# Altium schematic sheet microcontroller.SchDoc
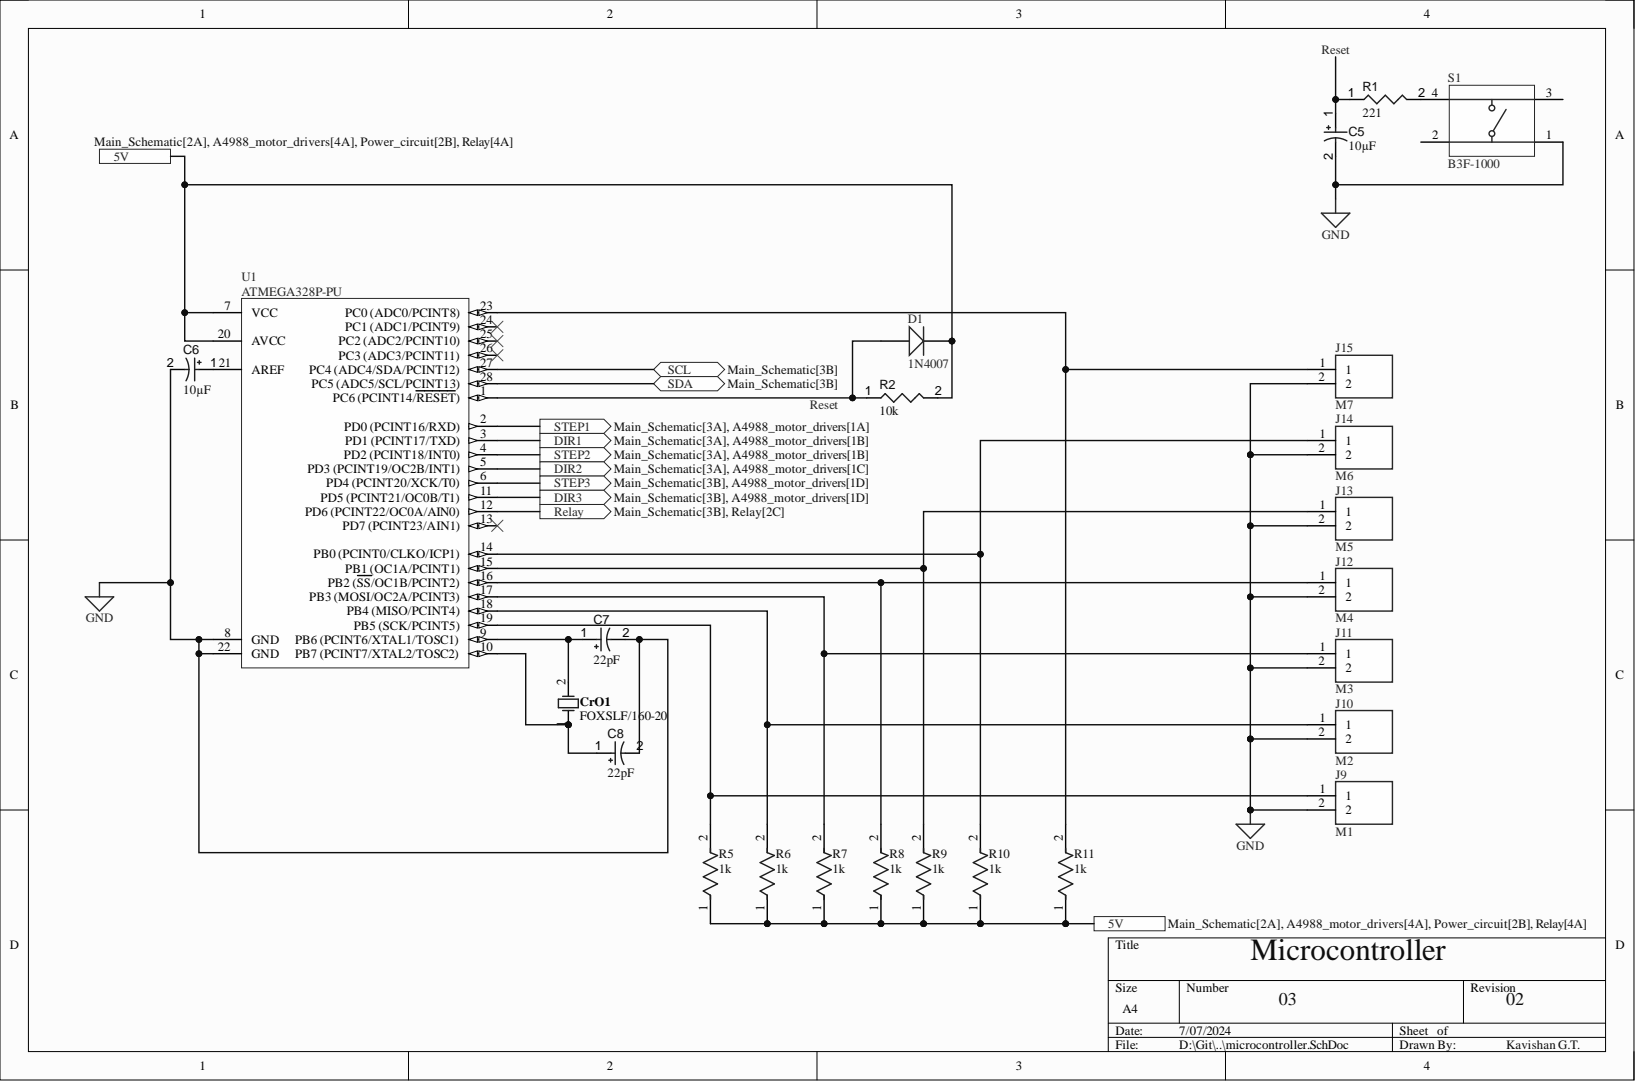
[diagram p0: microcontroller.SchDoc]

## Parts
C5  value 10µF  [CAPPR55-200-1100X500X1200]  (hidden comment: ECA1HM100)
C6  value 10µF  [CAPPR55-200-1100X500X1200]  (hidden comment: ECA1HM100)
C7  value 22pF  [CAPPR55-200-1100X500X1200]  (hidden comment: ECA1HM100)
C8  value 22pF  [CAPPR55-200-1100X500X1200]  (hidden comment: ECA1HM100)
CrO1  FOXSLF/160-20  [FOX-FOXSLF160-20]
D1  1N4007  [FAIR-DO-41-2]
J9  M1  [SHDR2W64P0X250_1X2_750X380X600P]
J10  M2  [SHDR2W64P0X250_1X2_750X380X600P]
J11  M3  [SHDR2W64P0X250_1X2_750X380X600P]
J12  M4  [SHDR2W64P0X250_1X2_750X380X600P]
J13  M5  [SHDR2W64P0X250_1X2_750X380X600P]
J14  M6  [SHDR2W64P0X250_1X2_750X380X600P]
J15  M7  [SHDR2W64P0X250_1X2_750X380X600P]
R1  value 221  [RESA60-630X240]  (hidden comment: MFR-25FBF52-221R)
R2  value 10k  [RESA60-630X240]  (hidden comment: MFR-25FBF52-10K)
R5  1k  [FP-MFR-25-MFG]
R6  1k  [FP-MFR-25-MFG]
R7  1k  [FP-MFR-25-MFG]
R8  1k  [FP-MFR-25-MFG]
R9  1k  [FP-MFR-25-MFG]
R10  1k  [FP-MFR-25-MFG]
R11  1k  [FP-MFR-25-MFG]
S1  B3F-1000  [OMRON-B3F-1000-4_V]
U1  ATMEGA328P-PU  [ATML-28P3]

## Ports
5V, DIR1, DIR2, DIR3, Relay, SCL, SDA, STEP1, STEP2, STEP3

## Nets
GND: C5.2 C6.2 C7.2 C8.2 J9.2 J10.2 J11.2 J12.2 J13.2 J14.2 J15.2 S1.1 U1.8(GND) U1.22(GND)
5V: D1.1 R2.2 R5.1 R6.1 R7.1 R8.1 R9.1 R10.1 R11.1 U1.7(VCC) U1.20(AVCC) ; port 5V
DIR1: U1.3(PD1_(PCINT17/TXD)) ; port DIR1
DIR2: U1.5(PD3_(PCINT19/OC2B/INT1)) ; port DIR2
DIR3: U1.11(PD5_(PCINT21/OC0B/T1)) ; port DIR3
Relay: U1.12(PD6_(PCINT22/OC0A/AIN0)) ; port Relay
Reset: C5.1 D1.2 R1.1 R2.1 U1.1(PC6_(PCINT14/RESET))
SCL: U1.27 ; port SCL
SDA: U1.28(PC5_(ADC5/SCL/PCINT13)) ; port SDA
STEP1: U1.2(PD0_(PCINT16/RXD)) ; port STEP1
STEP2: U1.4(PD2_(PCINT18/INT0)) ; port STEP2
STEP3: U1.6(PD4_(PCINT20/XCK/T0)) ; port STEP3
NetC6_1: C6.1 U1.21(AREF)
NetC7_1: C7.1 CrO1.2 U1.9
NetC8_1: C8.1 CrO1.1 U1.10
NetJ9_1: J9.1 R5.2 U1.19(PB5_(SCK/PCINT5))
NetJ10_1: J10.1 R6.2 U1.18(PB4_(MISO/PCINT4))
NetJ11_1: J11.1 R7.2 U1.17(PB3_(MOSI/OC2A/PCINT3))
NetJ12_1: J12.1 R8.2 U1.16(PB2_(SS/OC1B/PCINT2))
NetJ13_1: J13.1 R9.2 U1.15(PB1_(OC1A/PCINT1))
NetJ14_1: J14.1 R10.2 U1.14(PB0_(PCINT0/CLKO/ICP1))
NetJ15_1: J15.1 R11.2 U1.23(PC0_(ADC0/PCINT8))
NetR1_2: R1.2 S1.4

Not connected: S1 2 3; U1 13(PD7_(PCINT23/AIN1)) 24(PC1_(ADC1/PCINT9)) 25 26(PC3_(ADC3/PCINT11))

## Library data of the parts
C5-C8 = CMP-001-00025-7: Aluminum Electrolytic Capacitor, 10 uF, +/- 20%, 50 V, -40 to 85 degC, 2-Pin THD, RoHS, Bulk; Manufacturer: Panasonic; Ripple Current: 65mA; Voltage Rating: 50V; Capacitor Type: Electrolytic; Case/Package: Radial; Component Kind: Standard; Datasheet URL: https://industrial.panasonic.com/cdbs/www-data/pdf/RDF0000/ABA0000C1218.pdf; Datasheet Version: Rev. 05, 10/2016; Diameter: 5mm; Lead Pitch: 2mm; Manufacturer URL: http://www.panasonic.com/; Max Operating Temperature: 85°C; Min Operating Temperature: -40°C; Mounting Technology: TH; Package Description: 2-Pin Radial Lead, Dia 5 mm, Height 12 mm; Package Quantity: 200; Package Reference: CAPPR55-200-1100X500X1200; Packaging: Bulk; Pins: 2; Ripple Current (AC): 65mA; RoHS Compliant: True; Tolerance: 20%; Library Name: University of Moratuwa
CrO1 = CMP-1749-00003-1: Resistance Weld Thru-Hole Crystal, 16 MHz, -20 to 70 degC, 2-Pin THD, RoHS, Bulk; Case\Package: Axial; Power Rating: 250 mW; Resistance: 221 kohm; Tolerance: 1%; Voltage Rating: 250 V; Component Kind: Standard; ComponentLink1Description: Manufacturer URL; ComponentLink1URL: http://www.foxonline.com/; ComponentLink2Description: Datasheet; ComponentLink2URL: http://www.foxonline.com/pdfs/hc49slf.pdf; DatasheetVersion: Mar-15; Length: 0.248 inch; Library Name: Altium Content Vault; Max Operating Temperature: 155 degC; Min Operating Temperature: -55 degC; Mount: Through Hole; Package Quantity: 200; PackageDescription: 2-Pin Through Hole Device, Body 11.35 x 4.65 mm; PackageReference: FOXSLF160-20; Packaging: Bulk; RoHSCompliant: true
D1 = CMP-004-00007-2: General Purpose Rectifier, 1.1 V, 1.0 A, -55 to 175 degC, 2-Pin DO-41, RoHS, Tape and Reel; Manufacturer: Fairchild; Elements: 1; Forward Current: 1A; Forward Voltage: 1.1V; Max Surge Current: 30A; Package Version: Rev. 2, 09/2008; Peak Reverse Current: 5uA; Case/Package: DO-41-2; Component Kind: Standard; Datasheet URL: https://www.fairchildsemi.com/datasheets/1N/1N4007.pdf; Datasheet Version: Rev. 1.1.0, 11/2014; Manufacturer URL: https://www.fairchildsemi.com/; Max Operating Temperature: 175°C; Min Operating Temperature: -55°C; Mounting Technology: TH; Package Description: 2-Pin Axial Lead, Dia 2.375 mm, Body Length 4.63 mm; Package Quantity: 5000; Package Reference: DO-41-2; Package URL: http://www.fairchildsemi.com/dwg/DO/DO41A.pdf; Packaging: TapeandReel; Pins: 2; RoHS Compliant: True; Library Name: University of Moratuwa
J9-J15 = B2B-EH-A: Connector; Manufacturer_Name: JST (JAPAN SOLDERLESS TERMINALS); Component Kind: Standard; Datasheet Link: http://www.jst-mfg.com/product/pdf/eng/eEH.pdf; Height: 6mm; Manufacturer_Part_Number: B2B-EH-A
R1 = CMP-009-00051-4: Axial Resistor, 221 Ohm, +/- 1%, 250 mW, -55 to 155 degC, 2-Pin THD, RoHS, Bulk; Manufacturer: Yageo; Power: 250mW; Voltage Rating: 250V; Case/Package: Axial; Component Kind: Standard; Datasheet URL: http://www.yageo.com/documents/recent/Yageo%20LR_MFR_2013.pdf; Datasheet Version: Apr-13; Manufacturer URL: http://www.yageo.com/; Max Operating Temperature: 155°C; Min Operating Temperature: -55°C; Mounting Technology: TH; Package Description: 2-Pin Axial Lead, Dia 2.4 mm, Body Length 6.3 mm; Package Quantity: 200; Package Reference: MFR-25; Packaging: Bulk; RoHS Compliant: True; Tolerance: 1%; Library Name: University of Moratuwa
R2 = CMP-009-00047-4: Axial Resistor, 10 KOhm, +/- 1%, 0.25 W, -55 to 155 degC, 2-Pin THD, RoHS, Bulk; Manufacturer: Yageo; Power: 250mW; Voltage Rating: 250V; Case/Package: Axial; Component Kind: Standard; Datasheet URL: http://www.yageo.com/documents/recent/Yageo%20LR_MFR_2013.pdf; Datasheet Version: Apr-13; Manufacturer URL: http://www.yageo.com/; Max Operating Temperature: 155°C; Min Operating Temperature: -55°C; Mounting Technology: TH; Package Description: 2-Pin Axial Lead, Dia 2.4 mm, Body Length 6.3 mm; Package Quantity: 200; Package Reference: MFR-25; Packaging: Bulk; RoHS Compliant: True; Tolerance: 1%; Library Name: University of Moratuwa
R5-R11 = CMP-03413-000001-1: RES 1.18K OHM 1/4W 1% AXIAL; Manufacturer: Yageo; Manufacturer Part Number: MFR-25FBF52-1K18; Power Rating: 0.25W; Resistance: 1.18kΩ; Temperature Coefficient: 100ppm/°C; Value: 1.18kΩ; Voltage Rating: 250V; Automotive: No; Category: Res; Component Kind: Standard; ComponentLink2Description: Datasheet; ComponentLink2URL: https://www.yageo.com/upload/media/product/products/datasheet/lr/Yageo_LR_MFR_1.pdf; Composition: Metal Film; Device Class L1: Passive Components; Device Class L2: Resistors; Device Class L3: Through-Hole Resistors; Digikey Description: RES 1.18K OHM 1/4W 1% AXIAL; Height: 2.6mm; Ipc Land Pattern Name: RESAD1000W75L680D260; Lead Free: Yes; Library Name: Altium Content Vault; Mouser Description: Metal Film Resistors 1.18K OHM 1/4W 1%; Octopart: https://octopart.com/mfr-25fbf52-1k18-yageo-56251681; Package: MFR-25; RoHS: TRUE; Series: MFR; Temperature: -55°C to +155°C; Temperature Range High: +155°C; Temperature Range Low: -55°C; Tolerance: 1%
S1 = CMP-011-00004-2: Tactile Switch, SPST-NO, 0.05 A, 24 V, -25 to 70 degC, 4-Pin THD, RoHS, Bulk; Manufacturer: Omron Electronic Components; Contact Current Rating: 50mA; Max Contact Resistance: 100mohm; Max Voltage Rating (DC): 24V; Operating Force: 100gf; Resistance: 0.1Ohm; Throw Configuration: SPST; Case/Package: B3F-1000; Component Kind: Standard; Contact Material: Gold; Contact Plating: Gold; Current Rating: 50mA; Datasheet URL: http://www.omron.com/ecb/products/pdf/en-b3f.pdf; Datasheet Version: Jan-15; Manufacturer URL: http://www.omron.com/; Max Current Rating: 50mA; Max Operating Temperature: 70°C; Min Operating Temperature: -25°C; Mounting Technology: TH; Package Description: 4-Pin Through Hole Device, Body 6 x 6 mm; Package Quantity: 1500; Package Reference: B3F-1000; Packaging: Bulk; Pins: 4; RoHS Compliant: True; Voltage Rating: 24V; Voltage Rating (DC): 24V; Library Name: University of Moratuwa
U1 = CMP-020-00004-3: 8-Bit Microcontroller with 32 KBytes In-System Programmable Flash, 23 I/Os, -40 to 85 degC, 28-Pin PDIP (28P3), RoHS, Tube; Manufacturer: Atmel; Density: 32KB; Ethernet Channels: 0; I/Os: 23; Interface: USART; Max Clock Frequency: 20MHz; Max Supply Voltage: 5.5V; Min Supply Voltage: 1.8V; Package Version: Rev. B, 09/2001; RAM Size: 2KB; Case/Package: DIP; Component Kind: Standard; Datasheet URL: http://www.atmel.com/images/Atmel-8271-8-bit-AVR-Microcontroller-ATmega48A-48PA-88A-88PA-168A-168PA-328-328P_datasheet_Complete.pdf; Datasheet Version: Rev. 8271J, 11/2015; Manufacturer URL: http://www.atmel.com/; Max Operating Temperature: 85°C; Min Operating Temperature: -40°C; Mounting Technology: TH; Package Description: 28-Pin Plastic Dual Inline Package, Body 34.671 x 7.3025 mm, Pitch 2.54 mm; Package Quantity: 14; Package Reference: 28P3; Package URL: http://www.atmel.com/images/Atmel-8271-8-bit-AVR-Microcontroller-ATmega48A-48PA-88A-88PA-168A-168PA-328-328P_datasheet_Complete.pdf; Packaging: Rail/Tube; Pins: 28; RoHS Compliant: True; Library Name: University of Moratuwa
